# Altium schematic sheet TX-24SEG_ARDUINO.SchDoc
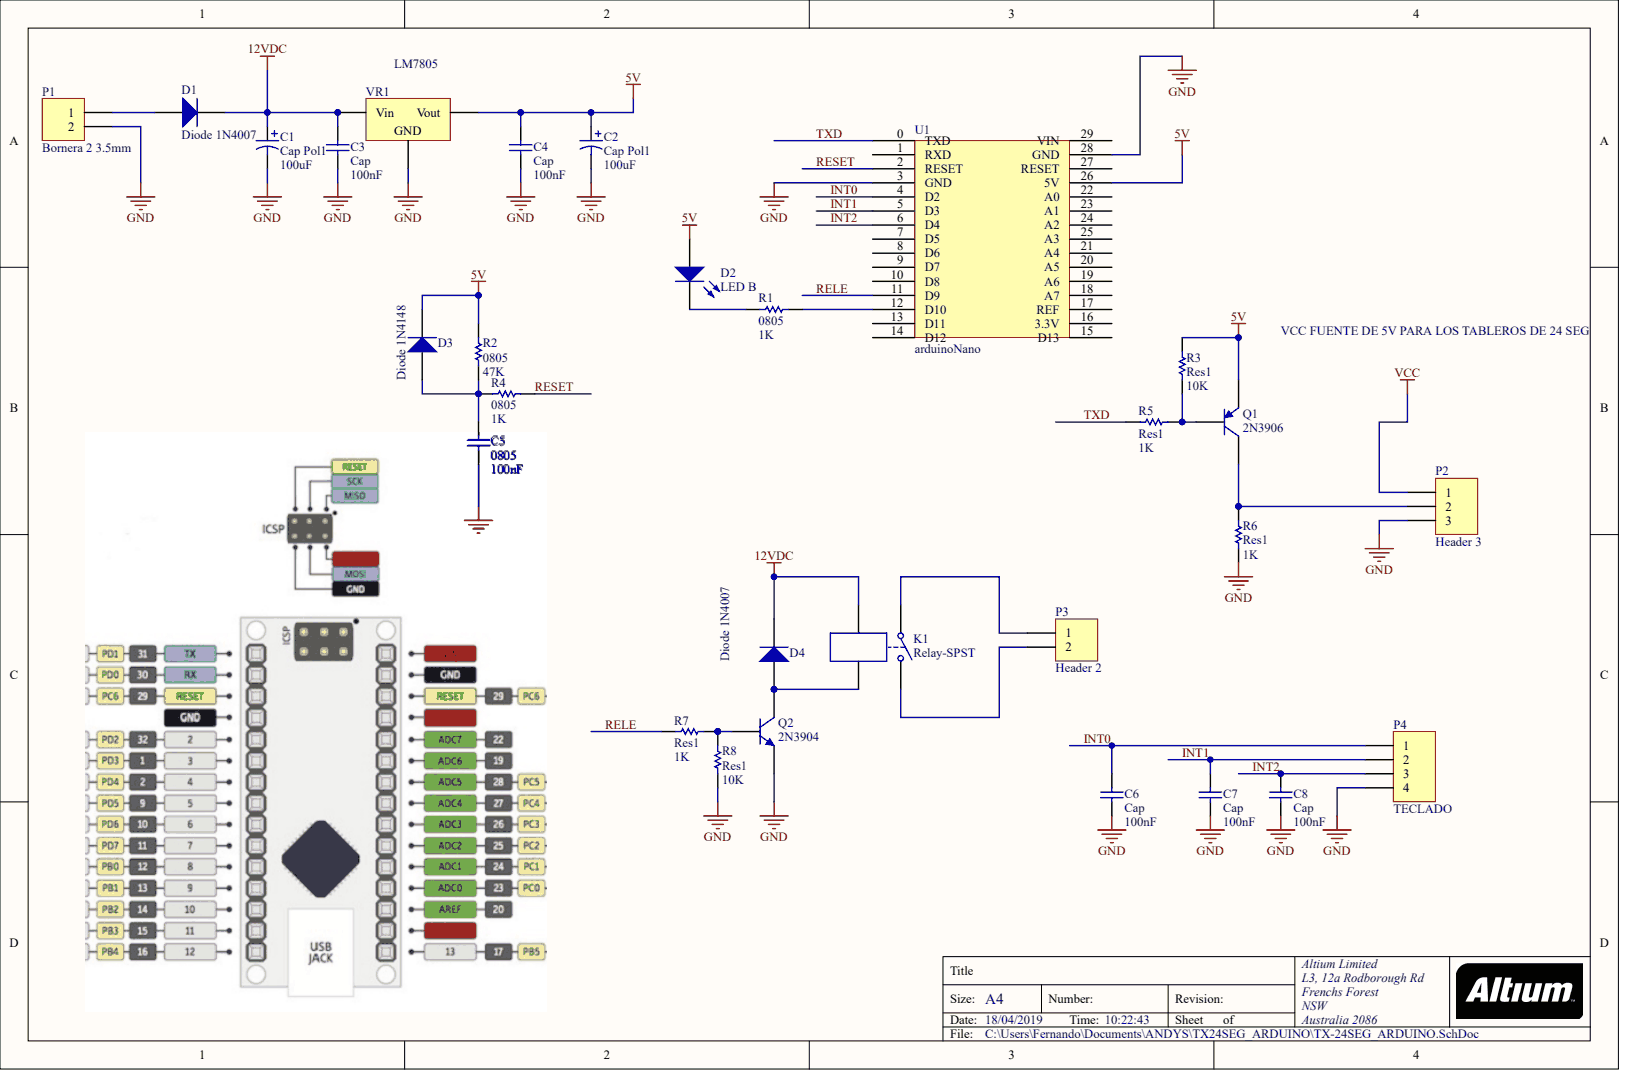
[diagram p0: TX-24SEG_ARDUINO.SchDoc]

## Parts
C1  Cap Pol1  value 100uF
C2  Cap Pol1  value 100uF
C3  Cap  value 100nF
C4  Cap  value 100nF
C5  0805  value 100nF
C6  Cap  value 100nF
C7  Cap  value 100nF
C8  Cap  value 100nF
D1  Diode 1N4007
D2  LED B
D3  Diode 1N4148
D4  Diode 1N4007
K1  Relay-SPST
P1  Bornera 2 3.5mm
P2  Header 3
P3  Header 2
P4  TECLADO
Q1  2N3906
Q2  2N3904
R1  0805  value 1K
R2  0805  value 47K
R3  Res1  value 10K
R4  0805  value 1K
R5  Res1  value 1K
R6  Res1  value 1K
R7  Res1  value 1K
R8  Res1  value 10K
U1  arduinoNano
VR1  LM7805

## Nets
5V: C2.1 C4.2 D2.1 D3.2 Q1.1 R2.2 R3.2 U1.26(5V) VR1.3
12VDC: C1.1 C3.2 D1.2 D4.2 K1.3 VR1.1
GND: C1.2 C2.2 C3.1 C4.1 C5.1 C6.1 C7.1 C8.1 P1.2 P2.3 P4.4 Q2.1 R6.1 R8.1 U1.3(GND) U1.28(GND) VR1.2
VCC: P2.1
INT0: C6.2 P4.1 U1.4(D2)
INT1: C7.2 P4.2 U1.5(D3)
INT2: C8.2 P4.3 U1.6(D4)
RELE: R7.1 U1.11(D9)
RESET: R4.2 U1.2(RESET)
TXD: R5.1 U1.0(TXD)
NetC5_2: C5.2 D3.1 R2.1 R4.1
NetD1_1: D1.1 P1.1
NetD2_2: D2.2 R1.1
NetD4_1: D4.1 K1.4 Q2.3
NetK1_1: K1.1 P3.1
NetK1_2: K1.2 P3.2
NetP2_2: P2.2 Q1.3 R6.2
NetQ1_2: Q1.2 R3.1 R5.2
NetQ2_2: Q2.2 R7.2 R8.2
NetR1_2: R1.2 U1.12(D10)

Not connected: U1 1(RXD) 7(D5) 8(D6) 9(D7) 10(D8) 13(D11) 14(D12) 15(D13) 16(3.3V) 17(REF) 18(A7) 19(A6) 20(A5) 21(A4) 22(A0) 23(A1) 24(A2) 25(A3) 27(RESET) 29(VIN)

## Library data of the parts
C5 = (no symbol name): Part: 399-1170-1-ND; Proveedor: Digikey; Published: 8-Jun-2000; LatestRevisionDate: 17-Jul-2002; LatestRevisionNote: Re-released for DXP Platform.; PackageReference: C1206; Price: 0.05; Publisher: Altium Limited
D2 = (no symbol name): Part: LTST-C171TBKT; Proveedor: Digikey; Published: 8-Jun-2000; LatestRevisionDate: 17-Jul-2002; LatestRevisionNote: Re-released for DXP Platform.; PackageVersion: Feb-2002; PackageReference: 3.2X1.6X1.1; Price: 0.44; Publisher: Altium Limited
D3 = (no symbol name): Part: 1N4148WTPMSCT; Proveedor: Digikey; Published: 8-Jun-2000; LatestRevisionDate: 17-Jul-2002; LatestRevisionNote: Re-released for DXP Platform.; PackageVersion: Sep-1996; PackageReference: SMC; Code_JEDEC: DO-214-AB; Price: 0.202; Publisher: Altium Limited
P1 = (no symbol name): Part: A98396-ND; Proveedor: Digikey; LatestRevisionDate: 17-Jul-2002; LatestRevisionNote: Re-released for DXP Platform.; Price: 0.08; Publisher: Altium Limited
Q2 = (no symbol name): Part: MMBT3904-7-F; Proveedor: Digikey; Published: 8-Jun-2000; LatestRevisionDate: 15-Jan-2003; LatestRevisionNote: PCB Footprint 'BCY-W3/D4.7' replaced; DatasheetDocument: 1997; PackageDocument: Sep-1998; PackageReference: TO-92A; Code_JEDEC: TO-92A; ComponentLink1Description: Manufacturer Link; ComponentLink1URL: http://www.fairchildsemi.com/; ComponentLink2Description: Datasheet; ComponentLink2URL: http://www.fairchildsemi.com/ds/2N%2F2N3904.pdf; Price: 0.12; Publisher: Altium Limited
R1 = (no symbol name): Part: 541-1.0KACT; Proveedor: Digikey; Published: 8-Jun-2000; LatestRevisionDate: 17-Jul-2002; LatestRevisionNote: Re-released for DXP Platform.; PackageReference: AXIAL-0.5; Price: 0.077; Publisher: Altium Limited
R2 = (no symbol name): Part: 541-47KACT; Proveedor: Digikey; Published: 8-Jun-2000; LatestRevisionDate: 17-Jul-2002; LatestRevisionNote: Re-released for DXP Platform.; PackageReference: AXIAL-0.5; Price: 0.077; Publisher: Altium Limited
R4 = (no symbol name): Part: 541-1.0KACT; Proveedor: Digikey; Value: 1K; Published: 8-Jun-2000; LatestRevisionDate: 17-Jul-2002; LatestRevisionNote: Re-released for DXP Platform.; PackageReference: AXIAL-0.5; Price: 0.077; Publisher: Altium Limited
C1-C4 C6-C8 D1 D4 K1 P2-P4 Q1 R3 R5-R8 U1 VR1 = (no symbol name)
  C1 C2: Published: 8-Jun-2000; LatestRevisionDate: 17-Jul-2002; LatestRevisionNote: Re-released for DXP Platform.; PackageReference: RB7.6-15; PackageDescription: Polarized Capacitor (Radial); 2 Leads
  C3 C4 C6-C8: Published: 8-Jun-2000; LatestRevisionDate: 17-Jul-2002; LatestRevisionNote: Re-released for DXP Platform.; PackageReference: RAD-0.3; PackageDescription: Radial Cap, Thru-Hole; 2 Leads; 0.3 in Pin Spacing
  D1 D4: Published: 8-Jun-2000; LatestRevisionDate: 17-Jul-2002; LatestRevisionNote: Re-released for DXP Platform.; DatasheetVersion: 1998; PackageVersion: Aug-1999; PackageReference: DO-41; PackageDescription: Diode, Axial; Body 5.2 x 2.7 mm (LxDia. max), Lead Dia. 0.86 mm (max); Code_JEDEC: DO-204-AL; ComponentLink1Description: Manufacturer Link; ComponentLink1URL: http://www.fairchildsemi.com/; ComponentLink2Description: Datasheet; ComponentLink2URL: http://www.fairchildsemi.com/ds/1N%2F1N4001.pdf
  K1: Published: 24-Mar-1999; LatestRevisionDate: 17-Jul-2002; LatestRevisionNote: Re-released for DXP Platform.; PackageReference: MODULE4
  P2-P4: LatestRevisionDate: 17-Jul-2002; LatestRevisionNote: Re-released for DXP Platform.
  Q1: Published: 8-Jun-2000; LatestRevisionDate: 15-Jan-2003; LatestRevisionNote: PCB Footprint 'BCY-W3/D4.7' replaced; DatasheetVersion: 1997; PackageVersion: Sep-1998; PackageReference: TO-92A; PackageDescription: TO, Flat Index; 3 In-Line, Axial Leads; Body Dia. 4.5mm; Leads 0.51 x 0.48 mm (max); ComponentLink1Description: Manufacturer Link; ComponentLink1URL: http://www.fairchildsemi.com/; ComponentLink2Description: Datasheet; ComponentLink2URL: http://www.fairchildsemi.com/ds/2N%2F2N3906.pdf
  R3 R5-R8: Published: 8-Jun-2000; LatestRevisionDate: 17-Jul-2002; LatestRevisionNote: Re-released for DXP Platform.; PackageReference: AXIAL-0.3; PackageDescription: Axial Device, Thru-Hole; 2 Leads; 0.3 in Pin Spacing
  VR1: Published: 24-Mar-1999; LatestRevisionDate: 29-May-2009; LatestRevisionNote: IPC-7351 Footprint Added.; PackageReference: D2PAK; PackageDescription: 3-Pin Transistor Outline Package 2.54 mm Pitch, 15.1 mm Lead Span
  C1-C4 C6-C8 D1 D4 K1 P2-P4 Q1 R3 R5-R8 VR1: Publisher: Altium Limited
